# Altium schematic sheet FPGA JTAG.SchDoc("FPGA JTAG")
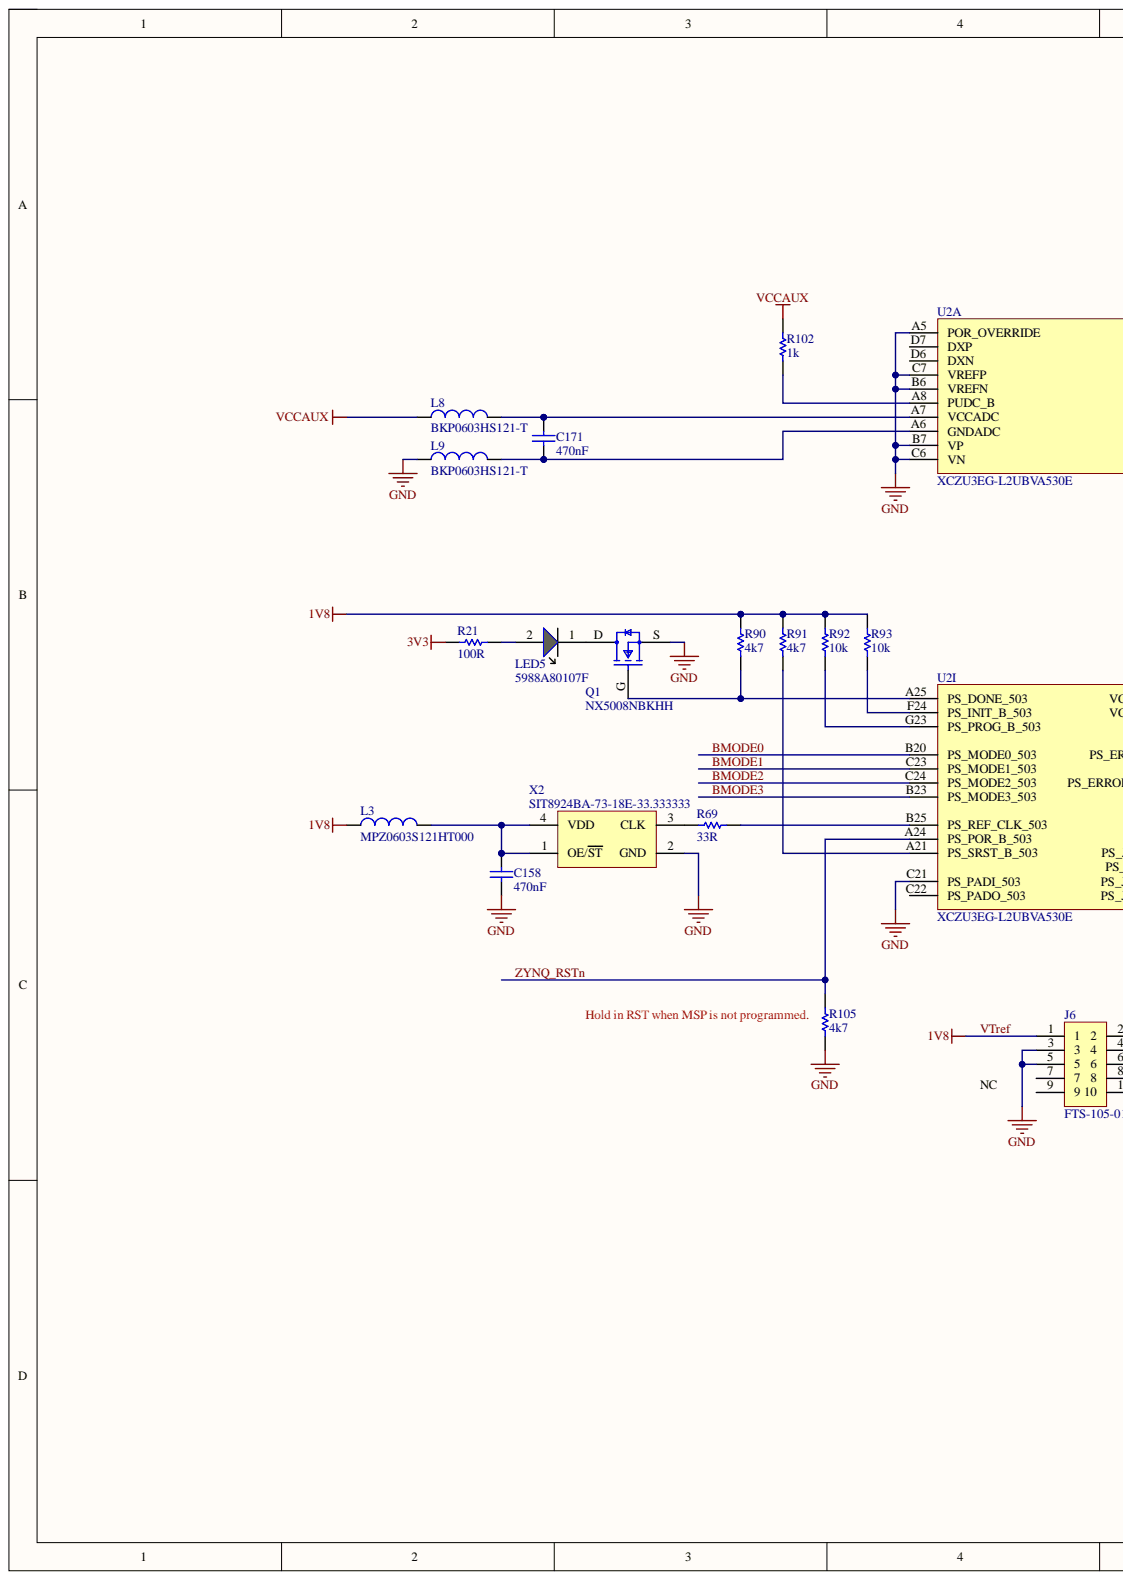
[diagram p0: FPGA JTAG.SchDoc, left]
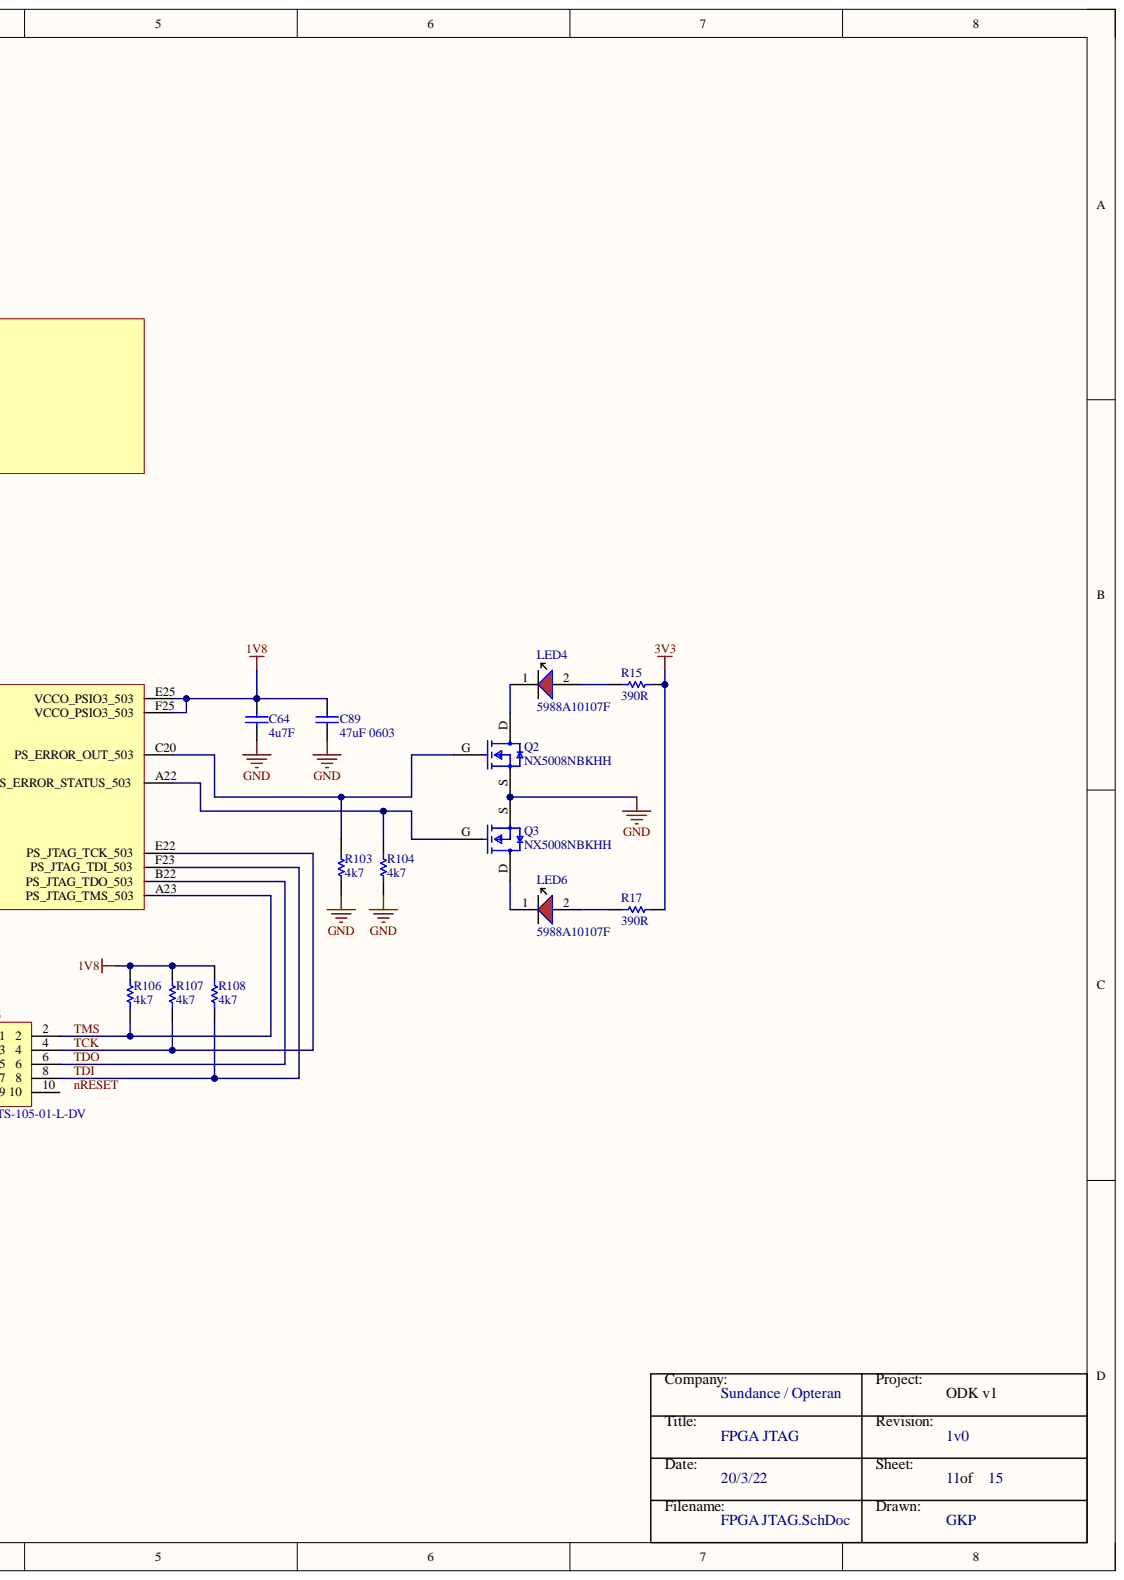
[diagram p1: FPGA JTAG.SchDoc, right]

## Parts
C64  4u7F  [0201panERJ]
C89  47uF 0603  Case-EIA: 0603  [CAP0603]
C158  470nF  [0201panERJ]
C171  470nF  [0201panERJ]
J6  FTS-105-01-L-DV  [SAMTEC-FTS-105-01-X-DV]
L3  MPZ0603S121HT000  [Inductor 0201]
L8  BKP0603HS121-T  [Inductor 0201]
L9  BKP0603HS121-T  [Inductor 0201]
LED4  5988A10107F  [LED0402 - RED]
LED5  5988A80107F  [LED0402 - GRN]
LED6  5988A10107F  [LED0402 - RED]
Q1  NX5008NBKHH  [DFN0606-3]
Q2  NX5008NBKHH  [DFN0606-3]
Q3  NX5008NBKHH  [DFN0606-3]
R15  390R  [RES0201]
R17  390R  [RES0201]
R21  100R  [RES0201]
R69  33R  [RES0201]
R90  4k7  [RES0201]
R91  4k7  [RES0201]
R92  10k  [RES0201]
R93  10k  [RES0201]
R102  1k  [RES0201]
R103  4k7  [RES0201]
R104  4k7  [RES0201]
R105  4k7  [RES0201]
R106  4k7  [RES0201]
R107  4k7  [RES0201]
R108  4k7  [RES0201]
U2  XCZU3EG-L2UBVA530E  [UBVA530]  (parts A,I)
X2  SIT8924BA-73-18E-33.333333  [QFN2014 4-pins OSC2x1.6mm]

## Nets
3V3: R15.1 R17.1 R21.2
GND: C64.1 C89.1 C158.1 J6.3 J6.5 L9.1 Q1.S Q2.S Q3.S R103.1 R104.1 R105.1 U2.A5(POR_OVERRIDE) U2.B6(VREFN) U2.B7(VP) U2.C6(VN) U2.C7(VREFP) U2.C21(PS_PADI_503) X2.2(GND)
VCCAUX: L8.1 R102.1
BMODE0: U2.B20(PS_MODE0_503)
BMODE1: U2.C23(PS_MODE1_503)
BMODE2: U2.C24(PS_MODE2_503)
BMODE3: U2.B23(PS_MODE3_503)
TCK: J6.4 R107.2 U2.E22(PS_JTAG_TCK_503)
TDI: J6.8 R108.2 U2.F23(PS_JTAG_TDI_503)
TDO: J6.6 U2.B22(PS_JTAG_TDO_503)
TMS: J6.2 R106.2 U2.A23(PS_JTAG_TMS_503)
VTref: C64.2 C89.2 J6.1 L3.1 R90.2 R91.2 R92.2 R93.2 R106.1 R107.1 R108.1 U2.E25(VCCO_PSIO3_503) U2.F25(VCCO_PSIO3_503)
ZYNQ_RSTn: R105.2 U2.A24(PS_POR_B_503)
NetC158_2: C158.2 L3.2 X2.1(OE/ST) X2.4(VDD)
NetC171_1: C171.1 L9.2 U2.A6(GNDADC)
NetC171_2: C171.2 L8.2 U2.A7(VCCADC)
NetLED4_1: LED4.1 Q2.D
NetLED4_2: LED4.2 R15.2
NetLED5_1: LED5.1 Q1.D
NetLED5_2: LED5.2 R21.1
NetLED6_1: LED6.1 Q3.D
NetLED6_2: LED6.2 R17.2
NetQ1_G: Q1.G R90.1 U2.A25(PS_DONE_503)
NetQ2_G: Q2.G R103.2 U2.C20(PS_ERROR_OUT_503)
NetQ3_G: Q3.G R104.2 U2.A22(PS_ERROR_STATUS_503)
NetR69_1: R69.1 X2.3(CLK)
NetR69_2: R69.2 U2.B25(PS_REF_CLK_503)
NetR91_1: R91.1 U2.A21(PS_SRST_B_503)
NetR92_1: R92.1 U2.G23(PS_PROG_B_503)
NetR93_1: R93.1 U2.F24(PS_INIT_B_503)
NetR102_2: R102.2 U2.A8(PUDC_B)
nRESET:

Not connected: J6 7 9 10; U2 C22(PS_PADO_503) D6(DXN) D7(DXP)

## Library data of the parts
C64 = Cap0201: 4u7F 0201 6V3 X5R; Case-EIA: 0402; Component Kind: Standard; Library Name: Schematic Components.SchLib; Max Thickness: 0.6 mm; Technology: SMT
C89 = Cap0603: 47uF 6V3 0603 X5R; Component Kind: Standard; Library Name: Schematic Components.SchLib; Max Thickness: 0.6 mm; Technology: SMT
C158 C171 = Cap0201: 470nF 0201 6V3 X5R; Case-EIA: 0402; Component Kind: Standard; Library Name: Schematic Components.SchLib; Max Thickness: 0.6 mm; Technology: SMT
J6 = FTS-105-01-L-DV: Connector Header Surface Mount 10 position 0.050" (1.27mm); Manufacturer 1: Samtec Inc.; Component Kind: Standard; Library Name: Schematic Components.SchLib; Manufacturer Part Number 1: FTS-105-01-L-DV; Supplier 1: Digi-Key; Supplier Part Number 1: SAM1157-05-ND
L3 = Inductor0201: 120 Ohms @ 100 MHz 1 Power Line Ferrite Bead 0201 (0603 Metric) 1A 130mOhm; Manufacturer 1: TDK Corporation; Component Kind: Standard; Library Name: Passive LCR.SchLib; Manufacturer Part Number 1: MPZ0603S121HT000; Supplier 1: Digikey; Supplier Part Number 1: 445-180338-2-ND
L8 L9 = Inductor0201: 120 Ohms @ 100 MHz 1 Power Line Ferrite Bead 0201 (0603 Metric) 850mA 150mOhm; Manufacturer 1: Taiyo Yuden; Component Kind: Standard; Library Name: Passive LCR.SchLib; Manufacturer Part Number 1: BKP0603HS121-T; Supplier 1: Digikey; Supplier Part Number 1: 587-3286-2-ND
LED4 LED6 = LED 0402 RED: Red 635nm LED Indication - Discrete 1.6V 0402 (1005 Metric); Manufacturer 1: DIALIGHT; Component Kind: Standard; Library Name: Schematic Components.SchLib; Manufacturer Part Number 1: 5988A10107F; Supplier 1: Digikey; Supplier Part Number 1: 350-4446-2-ND
LED5 = LED 0402 GRN: LED, Green, SMD, 0402 [1005 Metric], 5 mA, 2.5 V, 528 nm; Manufacturer 1: DIALIGHT; Component Kind: Standard; Library Name: Schematic Components.SchLib; Manufacturer Part Number 1: 5988A80107F; Supplier 1: Digikey; Supplier Part Number 1: 350-4451-2-ND
Q1-Q3 = FET NX5008NBKHH: N-Channel 50 V 350mA (Ta) 380mW (Ta), 2.8W (Tc) Surface Mount DFN0606-3; Manufacturer 1: Nexperia USA Inc.; Component Kind: Standard; Manufacturer Part Number 1: NX5008NBKHH; Supplier 1: Digi-Key; Supplier Part Number 1: 1727-NX5008NBKHHTR-ND; Library Name: Active Tran Diode.SchLib
R15 R17 = Res0201: 390R 0201 1/16W 1%; Value: ?k; Component Kind: Standard; Library Name: Schematic Components.SchLib
R21 = Res0201: 100R 0201 1/16W 1%; Value: ?k; Component Kind: Standard; Library Name: Schematic Components.SchLib
R69 = Res0201: 33R 0201 1/16W 1%; Value: ?k; Component Kind: Standard; Library Name: Schematic Components.SchLib
R90 R91 R103-R108 = Res0201: 4k7 0201 1/16W 1%; Value: ?k; Component Kind: Standard; Library Name: Schematic Components.SchLib
R92 R93 = Res0201: 10k 0201 1/16W 1%; Value: ?k; Component Kind: Standard; Library Name: Schematic Components.SchLib
R102 = Res0201: 1k 0201 1/16W 1%; Value: ?k; Component Kind: Standard; Library Name: Schematic Components.SchLib
U2 = XCZU3EG-L2UBVA530E: SoC 32-bit/64-bit ARM Cortex-A53 RISC 1.5GHz Quad Core 530-Pin BGA; Manufacturer 1: Xilinx; Component Kind: Standard; Library Name: Xilinx_Integrated_Library1.IntLib; Manufacturer Part Number 1: XCZU3EG-L2UBVA530E; Supplier 1: Avnet; Supplier Part Number 1: XCZU3EG-L2UBVA530E
X2 = OSC SiT8924B 2x1.6mm: 33.333333 MHz XO (Standard) LVCMOS, LVTTL Oscillator 1.8V Enable/Disable 4-SMD, No Lead. 2x1.6mm; Manufacturer 1: SiTime; Component Kind: Standard; Library Name: Schematic Components.SchLib; Manufacturer Part Number 1: SIT8924BA-73-18E-33.333333; Supplier 1: Digi-Key; Supplier Part Number 1: 1473-SIT8924BA-73-18E-33.333333-ND
